# Altium schematic sheet MCU-and-ICs.schdoc(MCU-and-ICs)
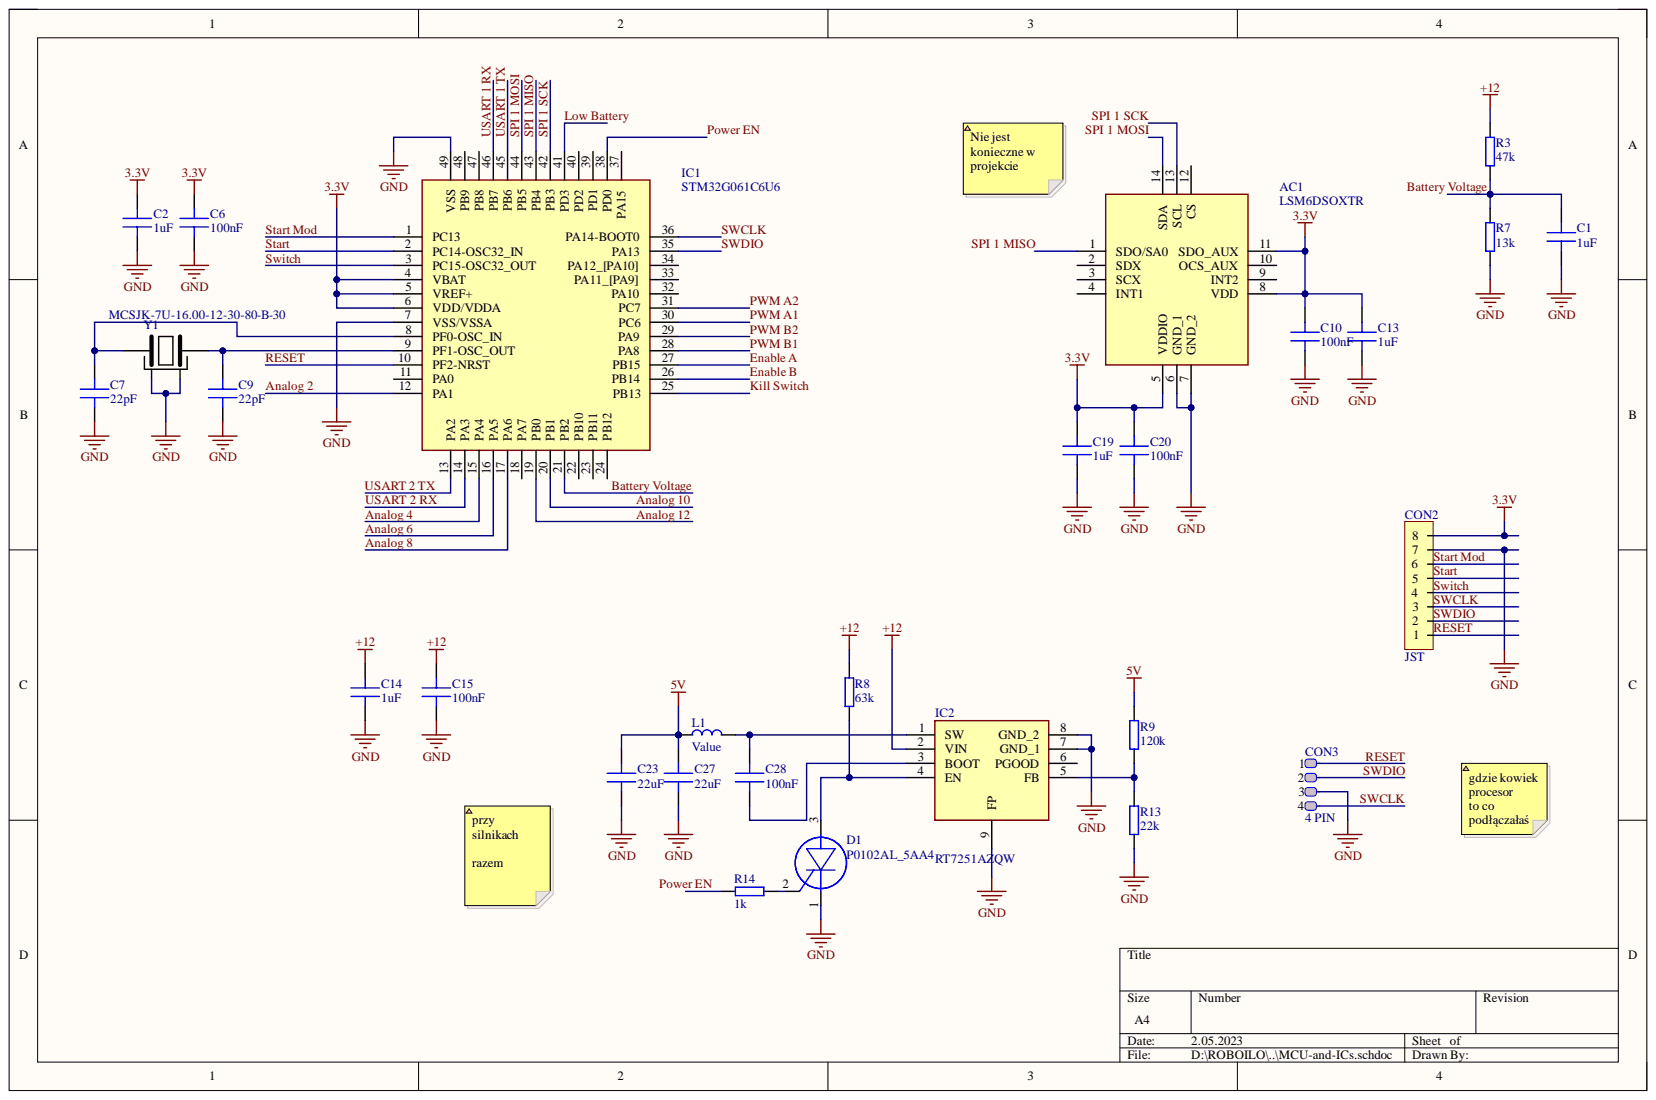
[diagram p0: MCU-and-ICs.schdoc]

## Parts
AC1  LSM6DSOXTR  [LGA-14_1]
C1  1uF  [C 0402]
C2  1uF  [C 0402]
C6  100nF  [C 0402]
C7  22pF  [C 0402]
C9  22pF  [C 0402]
C10  100nF  [C 0402]
C13  1uF  [C 0402]
C14  1uF  [C 0402]
C15  100nF  [C 0402]
C19  1uF  [C 0402]
C20  100nF  [C 0402]
C23  22uF  [C 0402]
C27  22uF  [C 0402]
C28  100nF  [C 0402]
CON2  JST  [BM08B-SRSS-TB]
CON3  4 PIN  [SM04B-SRSS-TB]
D1  P0102AL_5AA4  [SOT95P255X140-3N]
IC1  STM32G061C6U6  [QFN50P700X700X65-49N-D]
IC2  RT7251AZQW  [SON50P200X200X80-9N-D]
L1  Value  [L 0603]
R3  47k  [R 0402]
R7  13k  [R 0402]
R8  63k  [R 0402]
R9  120k  [R 0402]
R13  22k  [R 0402]
R14  1k  [R 0402]
Y1  MCSJK-7U-16.00-12-30-80-B-30  [MCSJK7U1600123080B30]

## Nets
3.3V: AC1.5(VDDIO) AC1.8(VDD) AC1.11(SDO_AUX) C2.1 C6.1 C10.1 C13.1 C19.1 C20.1 CON2.8 IC1.4(VBAT) IC1.5(VREF+) IC1.6(VDD/VDDA)
5V: C23.1 C27.1 L1.1 R9.2
+12: C14.1 C15.1 IC2.2(VIN) R3.2 R8.2
GND: AC1.6(GND_1) AC1.7(GND_2) C1.2 C2.2 C6.2 C7.2 C9.2 C10.2 C13.2 C14.2 C15.2 C19.2 C20.2 C23.2 C27.2 CON2.7 CON3.3 D1.1 IC1.7(VSS/VSSA) IC1.49(VSS) IC2.7(GND_1) IC2.8(GND_2) IC2.9(FP) R7.1 R13.1 Y1.2 Y1.4
Analog 2: IC1.12(PA1)
Analog 4: IC1.15(PA4)
Analog 6: IC1.16(PA5)
Analog 8: IC1.17(PA6)
Analog 10: IC1.20(PB1)
Analog 12: IC1.19(PB0)
Battery Voltage: C1.1 IC1.21(PB2) R3.1 R7.2
Enable A: IC1.27(PB15)
Enable B: IC1.26(PB14)
Kill Switch: IC1.25(PB13)
Low Battery: IC1.41(PD3)
Power EN: IC1.38(PD0) R14.2
PWM A1: IC1.30(PC6)
PWM A2: IC1.31(PC7)
PWM B1: IC1.28(PA8)
PWM B2: IC1.29(PA9)
RESET: CON2.1 CON3.1 IC1.10(PF2-NRST)
SPI 1 MISO: AC1.1(SDO/SA0) IC1.43(PB4)
SPI 1 MOSI: AC1.14(SDA) IC1.44(PB5)
SPI 1 SCK: AC1.13(SCL) IC1.42(PB3)
Start: CON2.5 IC1.2(PC14-OSC32_IN)
Start Mod: CON2.6 IC1.1(PC13)
SWCLK: CON2.3 CON3.4 IC1.36(PA14-BOOT0)
SWDIO: CON2.2 CON3.2 IC1.35(PA13)
Switch: CON2.4 IC1.3(PC15-OSC32_OUT)
USART 1 RX: IC1.46(PB7)
USART 1 TX: IC1.45(PB6)
USART 2 RX: IC1.14(PA3)
USART 2 TX: IC1.13(PA2)
NetC7_1: C7.1 IC1.8(PF0-OSC_IN) Y1.1
NetC9_1: C9.1 IC1.9(PF1-OSC_OUT) Y1.3
NetC28_1: C28.1 IC2.1(SW) L1.2
NetC28_2: C28.2 IC2.3(BOOT)
NetD1_2: D1.2 R14.1
NetD1_3: D1.3 IC2.4(EN) R8.1
NetIC2_5: IC2.5(FB) R9.1 R13.2

Not connected: AC1 2(SDX) 3(SCX) 4(INT1) 9(INT2) 10(OCS_AUX) 12(CS); IC1 11(PA0) 18(PA7) 22(PB10) 23(PB11) 24(PB12) 32(PA10) 33(PA11_[PA9]) 34(PA12_[PA10]) 37(PA15) 39(PD1) 40(PD2) 47(PB8) 48(PB9); IC2 6(PGOOD)

## Library data of the parts
AC1 = LSM6DSOXTR: Accelerometer; Manufacturer_Name: STMicroelectronics; Arrow Part Number: LSM6DSOXTR; Arrow Price/Stock: https://www.arrow.com/en/products/lsm6dsoxtr/stmicroelectronics; Component Kind: Standard; Datasheet Link: https://www.st.com/resource/en/datasheet/lsm6dsox.pdf; Library Name: SamacSys.SchLib; Manufacturer_Part_Number: LSM6DSOXTR; Mouser Part Number: 511-LSM6DSOXTR; Mouser Price/Stock: https://www.mouser.co.uk/ProductDetail/STMicroelectronics/LSM6DSOXTR?qs=l7cgNqFNU1i9dcjzItLpVQ%3D%3D
C1 C2 C6 C7 C9 C10 C13-C15 C19 C20 C23 C27 C28 = Capacitor: MLCC Capacitor; Component Kind: Standard; Library Name: Components.SchLib
CON2 = 8 Pin: Component Kind: Standard; Library Name: Connectors.SchLib
D1 = P0102AL_5AA4: SCR; Manufacturer_Name: STMicroelectronics; Manufacturer_Part_Number: P0102AL 5AA4; Arrow Part Number: P0102AL 5AA4; Arrow Price/Stock: https://www.arrow.com/en/products/p0102al5aa4/stmicroelectronics; Component Kind: Standard; Datasheet Link: https://www.st.com/resource/en/datasheet/p0102al.pdf; Height: 1.4mm; Library Name: SamacSys.SchLib; Mouser Part Number: 511-P0102AL; Mouser Price/Stock: https://www.mouser.co.uk/ProductDetail/STMicroelectronics/P0102AL-5AA4/?qs=PjVWedEN3CRKQvFRu1Tr6Q%3D%3D
IC1 = STM32G061C6U6: Integrated Circuit; Manufacturer_Name: STMicroelectronics; Component Kind: Standard; Datasheet Link: https://www.st.com/resource/en/datasheet/stm32g061c6.pdf; Height: 0.65mm; Library Name: SamacSys.SchLib; Manufacturer_Part_Number: STM32G061C6U6; Mouser Part Number: 511-STM32G061C6U6; Mouser Price/Stock: https://www.mouser.co.uk/ProductDetail/STMicroelectronics/STM32G061C6U6?qs=CiayqK2gdcKUDq0OUzUqqg%3D%3D
IC2 = RT7251AZQW: Integrated Circuit; Manufacturer_Name: RICHTEK; Component Kind: Standard; Datasheet Link: https://componentsearchengine.com/Datasheets/2/RT7251AZQW.pdf; Height: 0.8mm; Library Name: SamacSys.SchLib; Manufacturer_Part_Number: RT7251AZQW
L1 = Inductor: General pourpouse inductor; Component Kind: Standard; Library Name: Components.SchLib
R3 R7-R9 R13 R14 = Resistor: General pourpouse resistor; Component Kind: Standard; Library Name: Components.SchLib
Y1 = MCSJK-7U-16.00-12-30-80-B-30: Crystal or Oscillator; Manufacturer_Name: MULTICOMP; Component Kind: Standard; Datasheet Link: https://www.farnell.com/datasheets/2864166.pdf; Height: 0.8mm; Library Name: SamacSys.SchLib; Manufacturer_Part_Number: MCSJK-7U-16.00-12-30-80-B-30
CON3 = 4 PIN: Component Kind: Standard; Library Name: Connectors.SchLib
